# Altium schematic sheet RC96_decoder.SchDoc
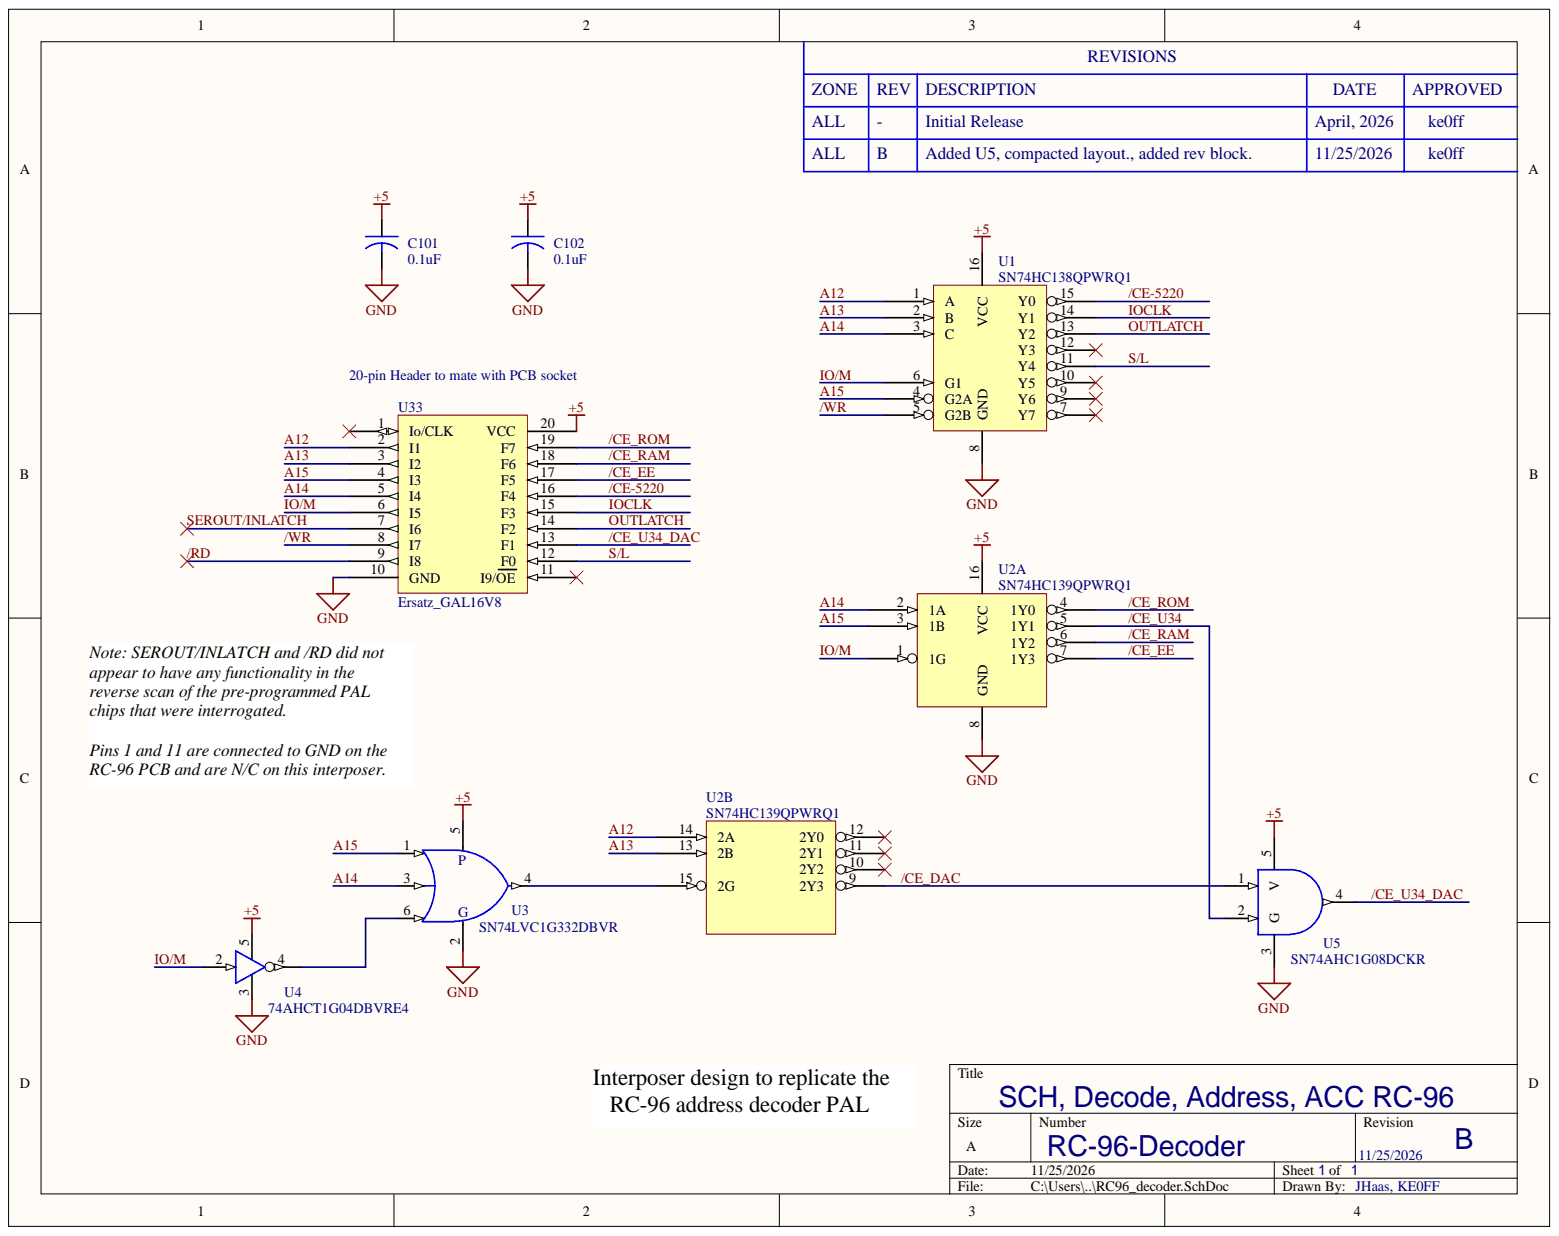
[diagram p0: RC96_decoder.SchDoc]

## Parts
C101  0.1uF  [0603]
C102  0.1uF  [0603]
U1  SN74HC138QPWRQ1  [SSOP16]
U2  SN74HC139QPWRQ1  [SSOP16]  (parts A,B)
U3  SN74LVC1G332DBVR  [SOT-23-6]
U4  74AHCT1G04DBVRE4  [SOT-23-5]
U5  SN74AHC1G08DCKR  [SC70-5]
U33  Ersatz_GAL16V8  [DIP20]

## Nets
+5: C101.1 C102.1 U1.16(VCC) U2.16(VCC) U3.5(P) U4.5 U5.5(V) U33.20(VCC)
GND: C101.2 C102.2 U1.8(GND) U2.8(GND) U3.2(G) U4.3 U5.3(G) U33.10(GND)
/CE-5220: U1.15(Y0) U33.16(F4)
/CE_DAC: U2.9(2Y3) U5.1
/CE_EE: U2.7(1Y3) U33.17(F5)
/CE_RAM: U2.6(1Y2) U33.18(F6)
/CE_ROM: U2.4(1Y0) U33.19(F7)
/CE_U34: U2.5(1Y1) U5.2
/CE_U34_DAC: U5.4 U33.13(F1)
/RD: U33.9(I8)
/WR: U1.5(G2B) U33.8(I7)
A12: U1.1(A) U2.14(2A) U33.2(I1)
A13: U1.2(B) U2.13(2B) U33.3(I2)
A14: U1.3(C) U2.2(1A) U3.3 U33.5(I4)
A15: U1.4(G2A) U2.3(1B) U3.1 U33.4(I3)
IO/M: U1.6(G1) U2.1(1G) U4.2 U33.6(I5)
IOCLK: U1.14(Y1) U33.15(F3)
OUTLATCH: U1.13(Y2) U33.14(F2)
S/L: U1.11(Y4) U33.12(F0)
SEROUT/INLATCH: U33.7(I6)
NetU2_15: U2.15(2G) U3.4
NetU3_6: U3.6 U4.4

Not connected: U1 7(Y7) 9(Y6) 10(Y5) 12(Y3); U2 10(2Y2) 11(2Y1) 12(2Y0); U33 1(Io/CLK) 11(I9/OE)

## Library data of the parts
C101 C102 = CAP 0805: CAP, TANT, 0805, 50V, 1uF; MFR: Wurth; MPN: 885012206095; ALT-MFR: -; ALT-MPN: -; Component Kind: Standard; DNP: -; Library Name: Galaxy SCH-jem-1.08.SchLib
U1 = 74HC138: IC, LGC, MUX, ACTLOW; MFR: TI; ALT-MPN: -; Component Kind: Standard; DNP: -; MPN: SN74HC138QPWRQ1; NOTES: -; NOTES2: -; PRI-MPN: -; Library Name: Galaxy SCH-jem-1.08.SchLib
U2 = 74HC139: IC, LGC, MUX, 1-4, DUAL, ACTLOW; MFR: TI; ALT-MPN: -; Component Kind: Standard; DNP: -; MPN: SN74HC139QPWRQ1; NOTES: -; NOTES2: -; PRI-MPN: -; Library Name: Galaxy SCH-jem-1.08.SchLib
U3 = 74LVC1G332: IC, LGC, 3-IN OR, SNGL; MFR: TI; ALT-MPN: -; Component Kind: Standard; DNP: -; MPN: SN74LVC1G332DBVR; NOTES: -; NOTES2: -; PRI-MPN: -; Library Name: Galaxy SCH-jem-1.08.SchLib
U4 = 74AHC1G04+5V: IC, LGC, NOT, SNGL; MFR: TI; ALT-MPN: -; Component Kind: Standard; DNP: -; MPN: 74AHCT1G04DBVRE4; NOTES: -; NOTES2: -; PRI-MPN: -; Library Name: Galaxy SCH-jem-1.08.SchLib
U5 = 74AHC1G08+5V: IC,GATE,2IN,AND,SNGL,; MFR: TI; ALT-MPN: -; Component Kind: Standard; DNP: -; MPN: SN74AHC1G08DCKR; NOTES: -; NOTES2: -; PRI-MPN: -; Library Name: Galaxy SCH-jem-1.08.SchLib
U33 = GAL16V8: IC, LGC, PAL, EE, 16V8; MFR: Aries; MPN: 20-600-11; MPN-ALT: 20-600-21; Component Kind: Standard; DNP: -; MFR-ALT: Aries; Library Name: Galaxy SCH-jem-1.08.SchLib
